# Altium schematic sheet Top_Level.SchDoc(Top_Level)
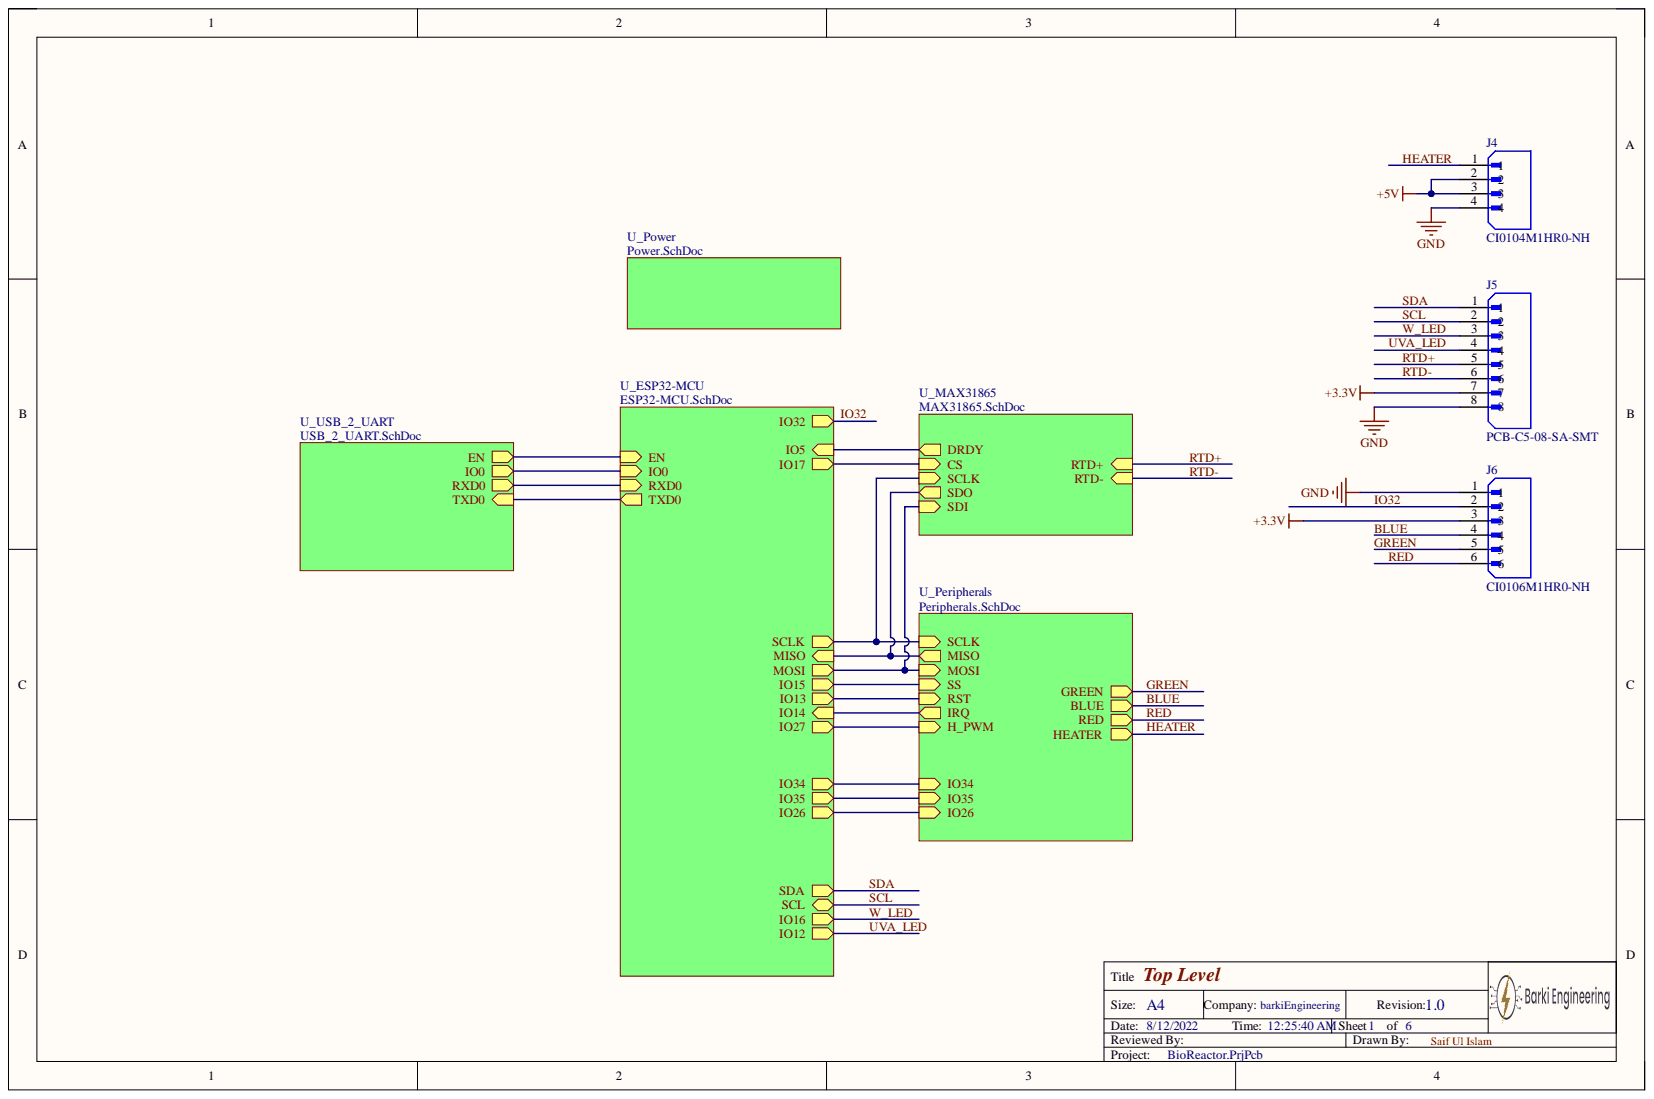
[diagram p0: Top_Level.SchDoc]

## Parts
J4  CI0104M1HR0-NH  [4 pos jst]
J5  PCB-C5-08-SA-SMT  [8 pos fpc]
J6  CI0106M1HR0-NH  [CVILUX_CI0106M1HR0-NH]

## Nets
+3.3V: J5.7 J6.3
+5V: J4.2 J4.3
GND: J4.4 J5.8 J6.1
BLUE: J6.4
GREEN: J6.5
HEATER: J4.1
IO32: J6.2
RED: J6.6
RTD+: J5.5
RTD-: J5.6
SCL: J5.2
SDA: J5.1
UVA_LED: J5.4
W_LED: J5.3
CS:
DRDY:
EN:
H_PWM:
IO0:
IO13:
IO14:
IO15:
IO26:
IO34:
IO35:
MISO:
MOSI:
RXD0:
SCLK:
TXD0:

## Library data of the parts
J4 = CI0104M1HR0-NH: 4 POS 2.00MM(.079) SINGLE ROW SM; MF: Cvilux USA; MP: CI0106M1HR0-NH; Check_prices: https://www.snapeda.com/parts/CI0106M1HR0-NH/CviLux/view-part/?ref=eda; Component Kind: Standard; Digi-Key_Part_Number: 2987-CI0106M1HR0-NHTR-ND; Package: None; Purchase-URL: https://pricing.snapeda.com/search/part/CI0106M1HR0-NH/?ref=eda; SnapEDA_Link: https://www.snapeda.com/parts/CI0106M1HR0-NH/CviLux/view-part/?ref=snap; Library Name: BioReactor.SCHLIB
J5 = PCB-C5-08-SA-SMT: FPC/FFC CONNECTOR, LIF TYPE, 1.0; MF: Cvilux USA; MP: CI0106M1HR0-NH; Check_prices: https://www.snapeda.com/parts/CI0106M1HR0-NH/CviLux/view-part/?ref=eda; Component Kind: Standard; Digi-Key_Part_Number: 2987-CI0106M1HR0-NHTR-ND; Package: None; Purchase-URL: https://pricing.snapeda.com/search/part/CI0106M1HR0-NH/?ref=eda; SnapEDA_Link: https://www.snapeda.com/parts/CI0106M1HR0-NH/CviLux/view-part/?ref=snap; Library Name: BioReactor.SCHLIB
J6 = CI0106M1HR0-NH: Connector Header Surface Mount, Right Angle 6 position 0.079 (2.00mm); MF: Cvilux USA; Check_prices: https://www.snapeda.com/parts/CI0106M1HR0-NH/CviLux/view-part/?ref=eda; Component Kind: Standard; Digi-Key_Part_Number: 2987-CI0106M1HR0-NHTR-ND; MP: CI0106M1HR0-NH; Package: None; Purchase-URL: https://pricing.snapeda.com/search/part/CI0106M1HR0-NH/?ref=eda; SnapEDA_Link: https://www.snapeda.com/parts/CI0106M1HR0-NH/CviLux/view-part/?ref=snap; Library Name: BioReactor.SCHLIB
